# Altium schematic sheet Single Channel Analyzer.SchDoc
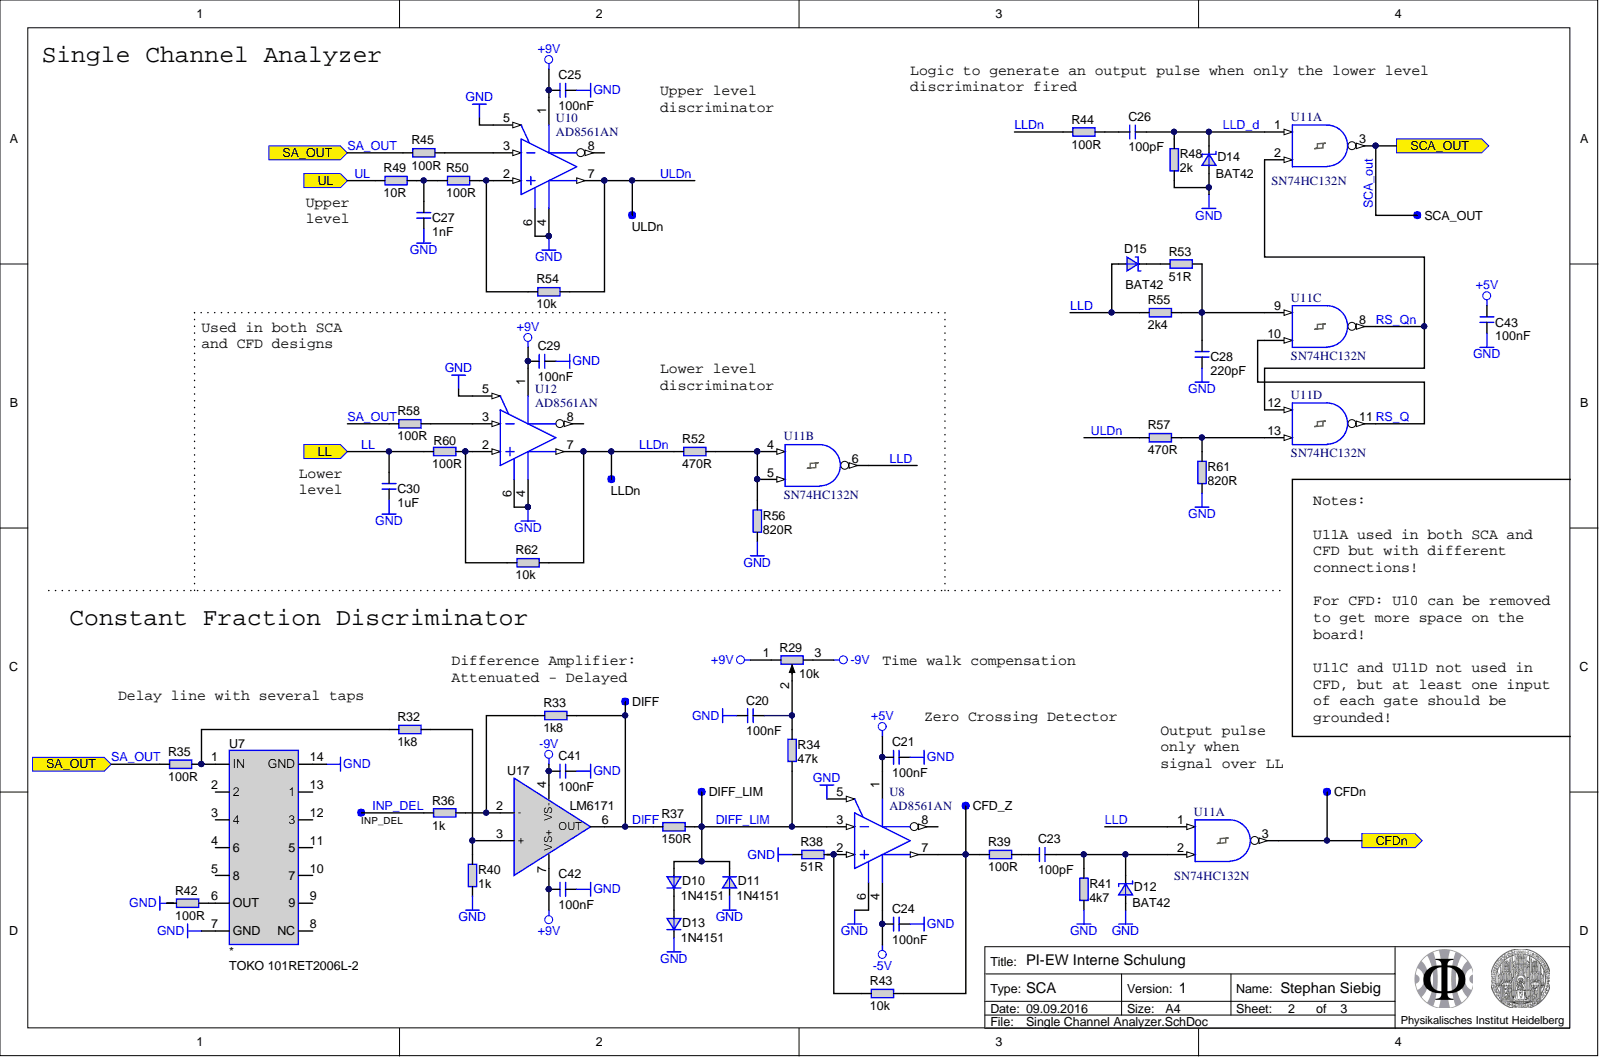
[diagram p0: Single Channel Analyzer.SchDoc]

## Parts
C20  value 100nF  [0805SMD]
C21  value 100nF  [0805SMD]
C23  value 100pF  [0805SMD]
C24  value 100nF  [0805SMD]
C25  value 100nF  [0805SMD]
C26  value 100pF  [0805SMD]
C27  value 1nF  [0805SMD]
C28  value 220pF  [0805SMD]
C29  value 100nF  [0805SMD]
C30  value 1uF  [0805SMD]
C41  value 100nF  [0805SMD]
C42  value 100nF  [0805SMD]
C43  value 100nF  [0805SMD]
CFD_Z  PAD  [PAD-2MM_1MM]  (value not drawn)  (no BOM)
CFDn  PAD  [PAD-2MM_1MM]  (value not drawn)  (no BOM)
D10  1N4151  [D-MINIMELF_SOD-80_1206SMD]
D11  1N4151  [D-MINIMELF_SOD-80_1206SMD]
D12  BAT42  [D-1206SMD]
D13  1N4151  [D-MINIMELF_SOD-80_1206SMD]
D14  BAT42  [D-1206SMD]
D15  BAT42  [D-1206SMD]
DIFF  PAD  [PAD-2MM_1MM]  (value not drawn)  (no BOM)
DIFF_LIM  PAD  [PAD-2MM_1MM]  (value not drawn)  (no BOM)
INP_DEL  PAD  [PAD-2MM_1MM]  (value not drawn)  (no BOM)
LLDn  PAD  [PAD-2MM_1MM]  (value not drawn)  (no BOM)
R29  value 10k  [PO-3299W]  (hidden comment: 3006P-1-XXX)
R32  value 1k8  [R-0603SMD]
R33  value 1k8  [R-0603SMD]
R34  value 47k  [R-0603SMD]
R35  value 100R  [R-0603SMD]
R36  value 1k  [R-0603SMD]
R37  value 150R  [R-0603SMD]
R38  value 51R  [R-0603SMD]
R39  value 100R  [R-0603SMD]
R40  value 1k  [R-0603SMD]
R41  value 4k7  [R-0603SMD]
R42  value 100R  [R-0603SMD]
R43  value 10k  [R-0603SMD]
R44  value 100R  [R-0603SMD]
R45  value 100R  [R-0603SMD]
R48  value 2k  [R-0603SMD]
R49  value 10R  [R-0603SMD]
R50  value 100R  [R-0603SMD]
R52  value 470R  [R-0603SMD]
R53  value 51R  [R-0603SMD]
R54  value 10k  [R-0603SMD]
R55  value 2k4  [R-0603SMD]
R56  value 820R  [R-0603SMD]
R57  value 470R  [R-0603SMD]
R58  value 100R  [R-0603SMD]
R60  value 100R  [R-0603SMD]
R61  value 820R  [R-0603SMD]
R62  value 10k  [R-0603SMD]
SCA_OUT  PAD  [PAD-2MM_1MM]  (value not drawn)  (no BOM)
U7  TOKO 101RET2006L-2  [DIP-14]
U8  AD8561AN  [DIP-8]
U10  AD8561AN  [DIP-8]  (no BOM)
U11  SN74HC132N  [DIP-14]  (no BOM)  (parts A,B,C,D)
U11  SN74HC132N  [DIP-14]  (part A)
U12  AD8561AN  [DIP-8]
U17  LM6171  [DIP-8]
ULDn  PAD  [PAD-2MM_1MM]  (value not drawn)  (no BOM)

## Ports
CFDN, LL, SA_OUT, SCA_OUT, UL

## Nets
+5V: C21.1 C43.2 U8.1 U11.14
+9V: C25.1 C29.1 C42.1 R29.1 U10.1 U12.1 U17.7(VS+)
-5V: C24.1 U8.4
-9V: C41.1 R29.3 U17.4(VS-)
GND: C20.1 C21.2 C24.2 C25.2 C27.2 C28.1 C29.2 C30.2 C41.2 C42.2 C43.1 D11.2 D12.2 D13.1 D14.2 R38.2 R40.2 R41.1 R42.2 R48.1 R56.1 R61.1 U7.7(GND) U7.14(GND) U8.5 U8.6 U10.4 U10.5 U10.6 U11.7 U12.4 U12.5 U12.6
DIFF: DIFF.1 R33.1 R37.2 U17.6(OUT)
DIFF_LIM: D10.2 D11.1 DIFF_LIM.1 R34.1 R37.1 U8.3
INP_DEL: INP_DEL.1 R36.2
LL: C30.1 R60.2 ; port LL
LLD: D15.2 R55.1 U11.1 U11.6
LLD_D: C26.1 D14.1 R48.2 U11.1
LLDN: LLDn.1 R44.2 R52.1 R62.1 U12.7
RS_Q: U11.10 U11.11
RS_QN: U11.2 U11.8 U11.12
SA_OUT: R35.2 R45.2 R58.2 ; port SA_OUT
SCA_OUT: SCA_OUT.1 U11.3 ; port SCA_OUT
UL: R49.1 ; port UL
ULDN: R54.1 R57.1 U10.7 ULDn.1
NetC20_2: C20.2 R29.2 R34.2
NetC23_1: C23.1 R39.1
NetC23_2: C23.2 D12.1 R41.2 U11.2
NetC26_2: C26.2 R44.1
NetC27_1: C27.1 R49.2 R50.2
NetC28_2: C28.2 R53.2 R55.2 U11.9
NetCFD_Z_1: CFD_Z.1 R39.2 R43.1 U8.7
NetCFDn_1: CFDn.1 U11.3 ; port CFDN
NetD10_1: D10.1 D13.2
NetD15_1: D15.1 R53.1
NetR32_1: R32.1 R40.1 U17.3(+)
NetR32_2: R32.2 R35.1 U7.1(IN)
NetR33_2: R33.2 R36.1 U17.2(-)
NetR38_1: R38.1 R43.2 U8.2
NetR42_1: R42.1 U7.6(OUT)
NetR45_1: R45.1 U10.3
NetR50_1: R50.1 R54.2 U10.2
NetR52_2: R52.2 R56.2 U11.4 U11.5
NetR57_2: R57.2 R61.2 U11.13
NetR58_1: R58.1 U12.3
NetR60_1: R60.1 R62.2 U12.2

Not connected: U7 2 3 4 5 8(NC) 9 10 11 12 13; U8 8; U10 8; U12 8

## Library data of the parts
C20 C21 C24 C25 C29 C41-C43 = CK-SMD: Keramikkondensator; PART NUMBER: 1612; Component Kind: Standard; Library Name: EW.SchLib
C23 C26 = CK-SMD: Keramikkondensator; PART NUMBER: 2270; Component Kind: Standard; Library Name: EW.SchLib
C27 = CK-SMD: Keramikkondensator; PART NUMBER: 2282; Component Kind: Standard; Library Name: EW.SchLib
C28 = CK-SMD: Keramikkondensator; PART NUMBER: 2274; Component Kind: Standard; Library Name: EW.SchLib
C30 = CK-SMD: Keramikkondensator; Component Kind: Standard; Library Name: EW.SchLib
CFD_Z CFDn DIFF DIFF_LIM INP_DEL LLDn SCA_OUT ULDn = PAD_1: Description: PAD; Library Name: EW.SchLib
D10 D11 D13 = D-SMD: Diode; PART NUMBER: 644; Component Kind: Standard; PART TYPE: 1N4151; Library Name: EW.SchLib
D12 D14 D15 = ZD-SMD: Schottky-Diode; PART NUMBER: 646; Component Kind: Standard; PART TYPE: BAT42; Library Name: EW.SchLib
R29 = PO-3006P: Potentiometer; PART NUMBER: 2016; Component Kind: Standard; PART TYPE: 3006P-1-XXX; Library Name: EW.SchLib
R32-R42 R44 R45 R48-R50 R52 R53 R55-R58 R60 R61 = R-SMD: Widerstand; Component Kind: Standard; Library Name: EW.SchLib
R43 R54 R62 = R-SMD: Widerstand; PART NUMBER: 6516; Component Kind: Standard; Library Name: EW.SchLib
U7 = TOKO 101RET2006L-2: Delay Line; PART NUMBER: 889; Component Kind: Standard; PART TYPE: TOKO 101RET2006L-2; Library Name: EW-User.SchLib
U8 U10 U12 = AD8561AN: Comparator; Manufacturer: Analog Devices; Input Range (V): -5 to 3; Packing: Tube; Total Power (mW): 65mW; Voltage Supply (V): +/- 5; Code_JEDEC: MS-001; ComponentLink1Description: Manufacturer URL; ComponentLink1URL: http://www.analog.com/en/index.html; ComponentLink2Description: Datasheet; ComponentLink2URL: http://www.analog.com/static/imported-files/data_sheets/AD8561.pdf; ComponentLink3Description: Package Specification; ComponentLink3URL: http:/www.analog.com/static/imported-files/packages/PKG_PDF/PDIP(N)/N_8.pdf; ComponentLink4Description: URL Link1; ComponentLink4URL: Product URL:http://www.analog.com/en/special-linear-functions/comparators/ad8561/products/product.html; DatasheetVersion: Rev. 0; Mounting Technology: Through Hole; PackageDescription: 8-Pin Plastic Dual In-Line Package, Row spacing 7.62 mm, Pitch 2.54 mm; PackageReference: N-8; PackageVersion: 070606-A, 07/2006; PartNumber: AD8561AN; PART TYPE: AD8561AN; Supplier 1: Digi-Key; Supplier 2: Mouser; Supplier Part Number 1: AD8561AN-ND; Supplier Part Number 2: N/A; Library Name: Analog Devices Amplifiers and Linear Comparators.IntLib
  U8 U12: Component Kind: Standard
U11 U11 = SN74HC132N: Quadruple Positive-NAND Gate with Schmitt-Trigger Inputs; ComponentLink1Description: Manufacturer Link; ComponentLink1URL: http://www.ti.com/; ComponentLink2Description: Datasheet; ComponentLink2URL: http://www-s.ti.com/sc/ds/sn74hc132.pdf; DatasheetVersion: May-1997; LatestRevisionDate: 04-Mar-2005; LatestRevisionNote: Stylized 3D Model Added.; Library Name: TI Logic Gate 2.IntLib; PackageDescription: DIP; 14 Leads; Row Spacing 7.62 mm; Pitch 2.54 mm; PackageReference: N014; PackageVersion: Oct-1995; PART TYPE: SN74HCT132N; PCB3D: N014; Published: 8-Jun-2000; Signal Integrity: SN74HC132N; Publisher: Altium Limited
  U11: Component Kind: Standard
U17 = OP-STANDARD_1CH_8P: Operationsverstärker; Component Kind: Standard; PART TYPE: LM6171; Library Name: EW.SchLib
